# Altium schematic sheet RCU_v2_Logic.SchDoc(RCU_v2_Logic)
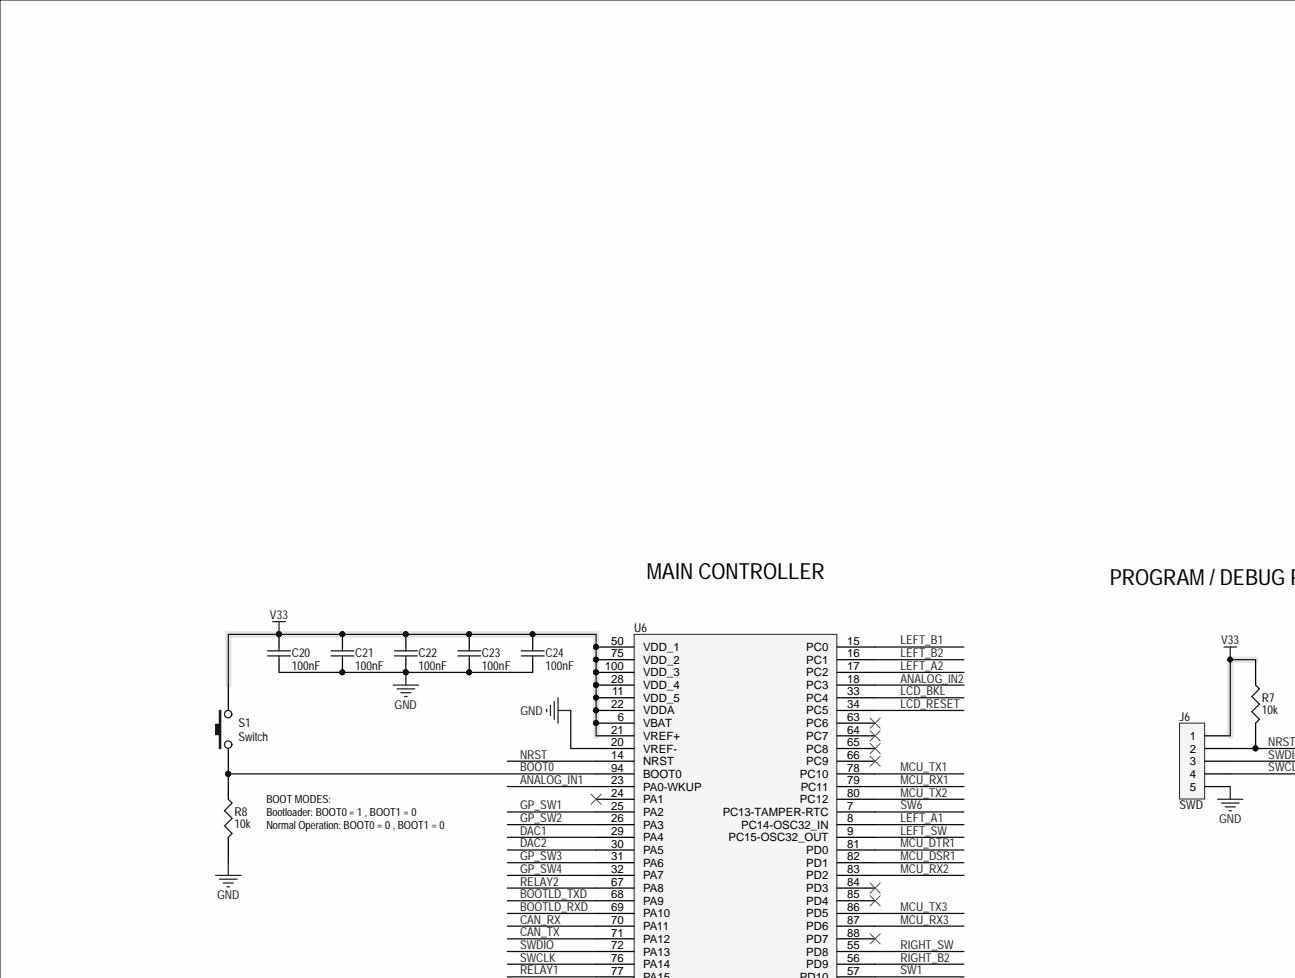
[diagram p0: RCU_v2_Logic.SchDoc, top left]
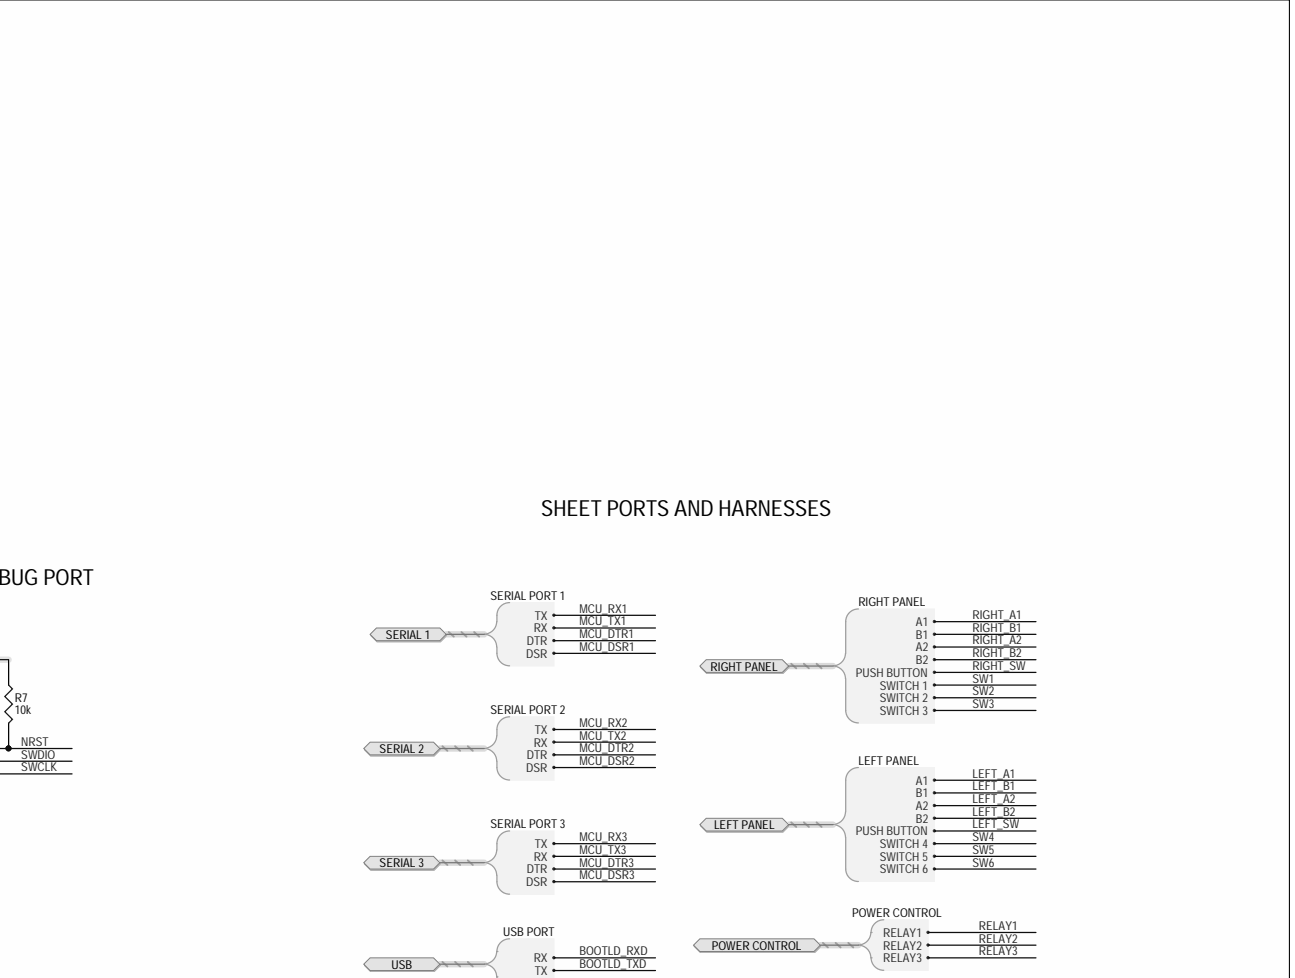
[diagram p1: RCU_v2_Logic.SchDoc, top right]
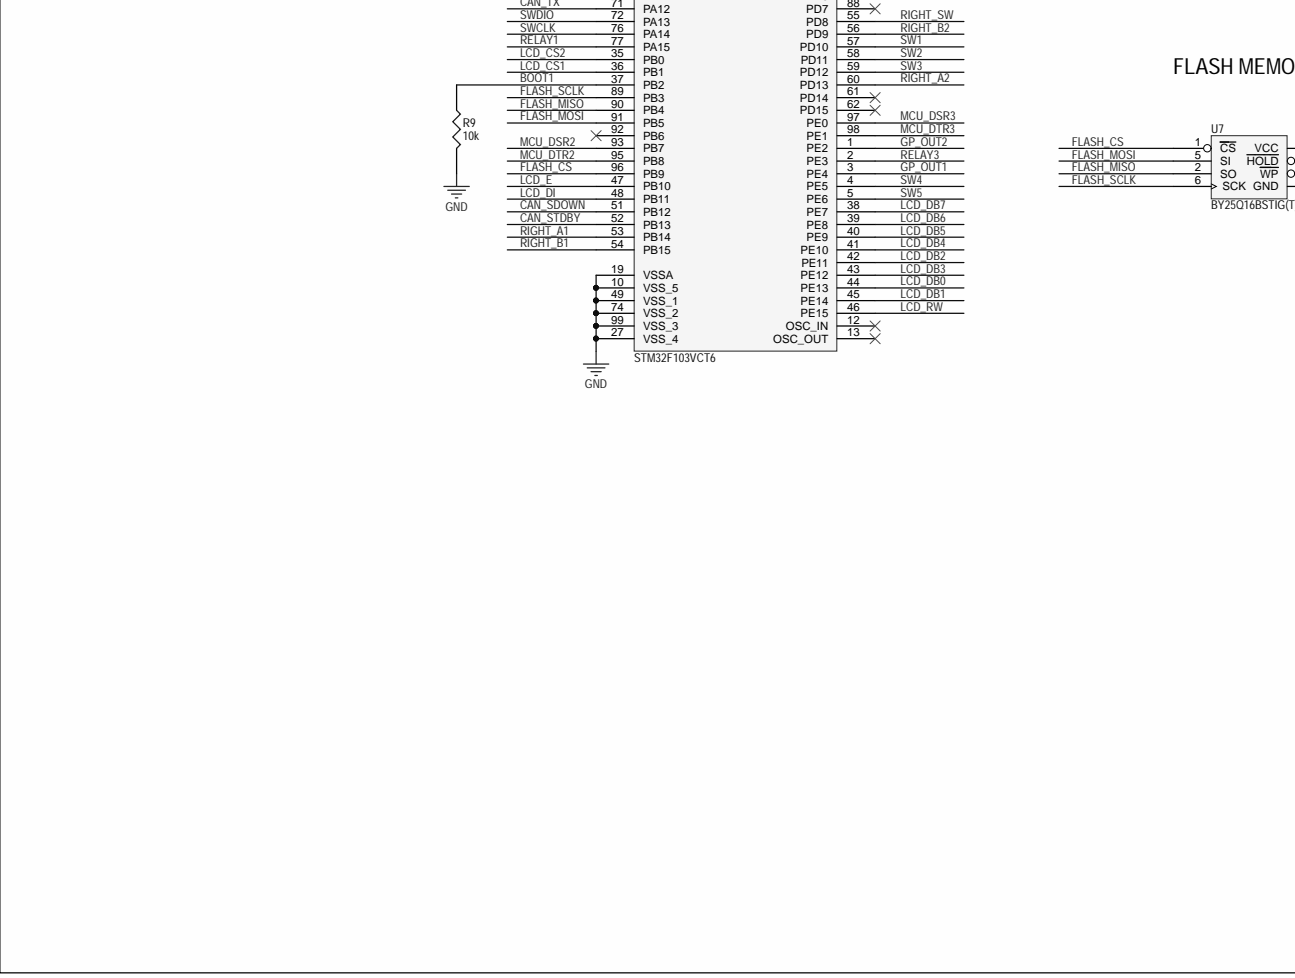
[diagram p2: RCU_v2_Logic.SchDoc, bottom left]
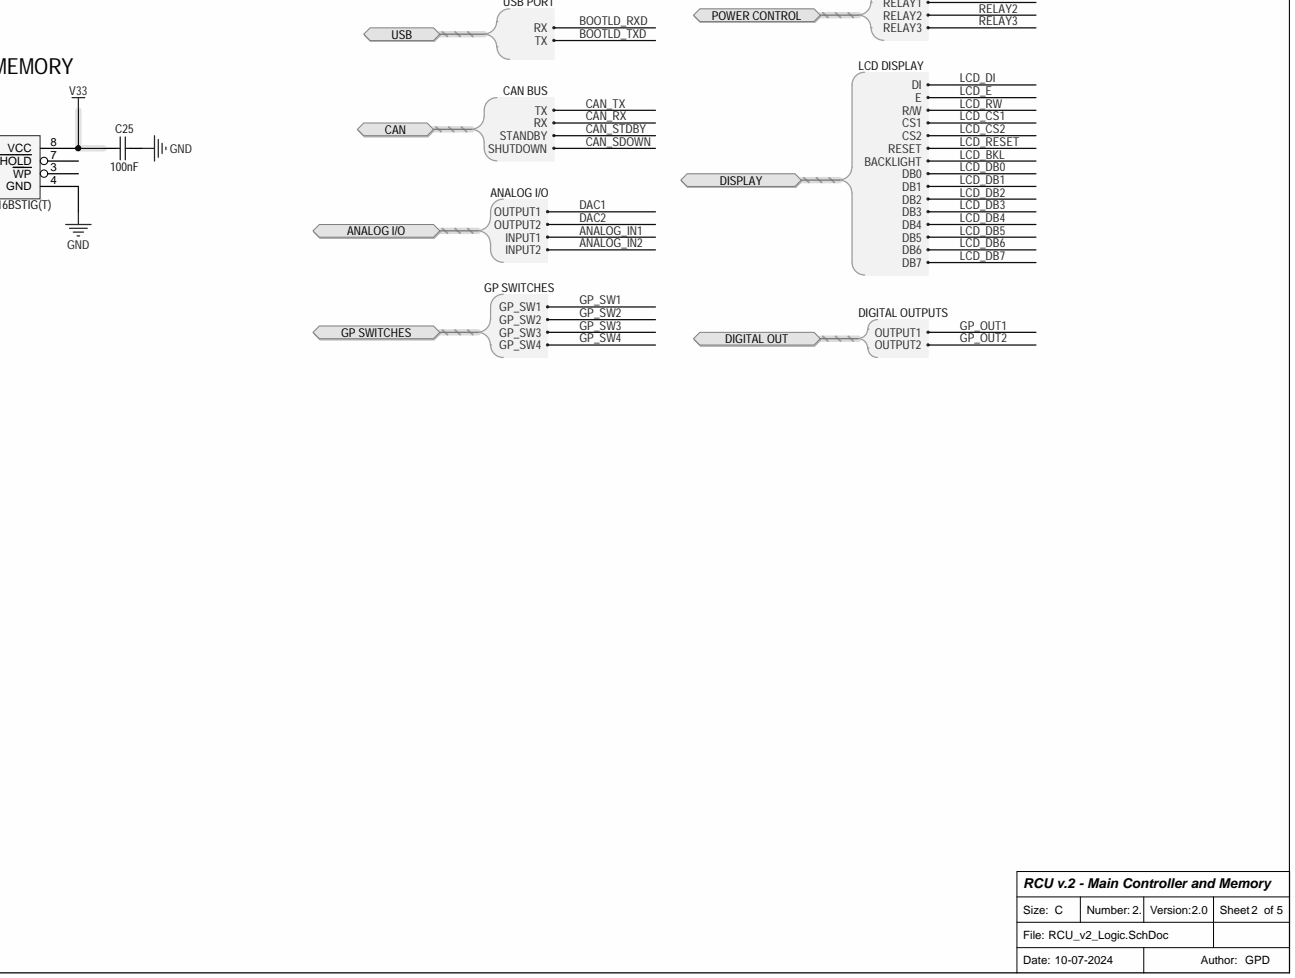
[diagram p3: RCU_v2_Logic.SchDoc, bottom right]

## Parts
C20  100nF  [C0402]
C21  100nF  [C0402]
C22  100nF  [C0402]
C23  100nF  [C0402]
C24  100nF  [C0402]
C25  100nF  [C0402]
J6  SWD  [HDR1X5]
R7  10k  [R0402]
R8  10k  [R0402]
R9  10k  [R0402]
S1  Switch  [SWITCH_A06_RA]
U6  STM32F103VCT6  [F-QFP14X14-G100/N]
U7  BY25Q16BSTIG(T)  [SO-G8]

## Ports
ANALOG I/O, ANALOG I/O.INPUT1, ANALOG I/O.INPUT2, ANALOG I/O.OUTPUT1, ANALOG I/O.OUTPUT2, CAN, CAN.RX, CAN.SHUTDOWN, CAN.STANDBY, CAN.TX, DIGITAL OUT, DIGITAL OUT.OUTPUT1, DIGITAL OUT.OUTPUT2, DISPLAY, DISPLAY.BACKLIGHT, DISPLAY.CS1, DISPLAY.CS2, DISPLAY.DB0, DISPLAY.DB1, DISPLAY.DB2, DISPLAY.DB3, DISPLAY.DB4, DISPLAY.DB5, DISPLAY.DB6, DISPLAY.DB7, DISPLAY.DI, DISPLAY.E, DISPLAY.R/W, DISPLAY.RESET, GP SWITCHES, GP SWITCHES.GP_SW1, GP SWITCHES.GP_SW2, GP SWITCHES.GP_SW3, GP SWITCHES.GP_SW4, LEFT PANEL, LEFT PANEL.A1, LEFT PANEL.A2, LEFT PANEL.B1, LEFT PANEL.B2, LEFT PANEL.PUSH BUTTON, LEFT PANEL.SWITCH 4, LEFT PANEL.SWITCH 5, LEFT PANEL.SWITCH 6, POWER CONTROL, POWER CONTROL.RELAY1, POWER CONTROL.RELAY2, POWER CONTROL.RELAY3, RIGHT PANEL, RIGHT PANEL.A1, RIGHT PANEL.A2, RIGHT PANEL.B1, RIGHT PANEL.B2, RIGHT PANEL.PUSH BUTTON, RIGHT PANEL.SWITCH 1, RIGHT PANEL.SWITCH 2, RIGHT PANEL.SWITCH 3, SERIAL 1, SERIAL 1.DSR, SERIAL 1.DTR, SERIAL 1.RX, SERIAL 1.TX, SERIAL 2, SERIAL 2.DSR, SERIAL 2.DTR, SERIAL 2.RX, SERIAL 2.TX, SERIAL 3, SERIAL 3.DSR, SERIAL 3.DTR, SERIAL 3.RX, SERIAL 3.TX, USB, USB.RX, USB.TX

## Nets
GND: C20.2 C21.2 C22.2 C23.2 C24.2 C25.1 J6.5 R8.2 R9.2 U6.10(VSS_5) U6.19(VSSA) U6.20(VREF-) U6.27(VSS_4) U6.49(VSS_1) U6.74(VSS_2) U6.99(VSS_3) U7.4(GND)
V33: C20.1 C21.1 C22.1 C23.1 C24.1 C25.2 J6.1 R7.1 S1.1 U6.6(VBAT) U6.11(VDD_5) U6.21(VREF+) U6.22(VDDA) U6.28(VDD_4) U6.50(VDD_1) U6.75(VDD_2) U6.100(VDD_3) U7.8(VCC)
ANALOG_IN1: U6.23(PA0-WKUP) ; port ANALOG I/O
ANALOG_IN2: U6.18(PC3) ; port ANALOG I/O
BOOT0: R8.1 S1.2 U6.94(BOOT0)
BOOT1: R9.1 U6.37(PB2)
BOOTLD_RXD: U6.69(PA10) ; port USB
BOOTLD_TXD: U6.68(PA9) ; port USB
CAN_RX: U6.70(PA11) ; port CAN
CAN_SDOWN: U6.51(PB12) ; port CAN
CAN_STDBY: U6.52(PB13) ; port CAN
CAN_TX: U6.71(PA12) ; port CAN
DAC1: U6.29(PA4) ; port ANALOG I/O
DAC2: U6.30(PA5) ; port ANALOG I/O
FLASH_CS: U6.96(PB9) U7.1(CS)
FLASH_MISO: U6.90(PB4) U7.2(SO)
FLASH_MOSI: U6.91(PB5) U7.5(SI)
FLASH_SCLK: U6.89(PB3) U7.6(SCK)
GP_OUT1: U6.3(PE4) ; port DIGITAL OUT
GP_OUT2: U6.1(PE2) ; port DIGITAL OUT
GP_SW1: U6.25(PA2) ; port GP SWITCHES
GP_SW2: U6.26(PA3) ; port GP SWITCHES
GP_SW3: U6.31(PA6) ; port GP SWITCHES
GP_SW4: U6.32(PA7) ; port GP SWITCHES
LCD_BKL: U6.33(PC4) ; port DISPLAY
LCD_CS1: U6.36(PB1) ; port DISPLAY
LCD_CS2: U6.35(PB0) ; port DISPLAY
LCD_DB0: U6.44(PE13) ; port DISPLAY
LCD_DB1: U6.45(PE14) ; port DISPLAY
LCD_DB2: U6.42(PE11) ; port DISPLAY
LCD_DB3: U6.43(PE12) ; port DISPLAY
LCD_DB4: U6.41(PE10) ; port DISPLAY
LCD_DB5: U6.40(PE9) ; port DISPLAY
LCD_DB6: U6.39(PE8) ; port DISPLAY
LCD_DB7: U6.38(PE7) ; port DISPLAY
LCD_DI: U6.48(PB11) ; port DISPLAY
LCD_E: U6.47(PB10) ; port DISPLAY
LCD_RESET: U6.34(PC5) ; port DISPLAY
LCD_RW: U6.46(PE15) ; port DISPLAY
LEFT_A1: U6.8(PC14-OSC32_IN) ; port LEFT PANEL
LEFT_A2: U6.17(PC2) ; port LEFT PANEL
LEFT_B1: U6.15(PC0) ; port LEFT PANEL
LEFT_B2: U6.16(PC1) ; port LEFT PANEL
LEFT_SW: U6.9(PC15-OSC32_OUT) ; port LEFT PANEL
MCU_DSR1: U6.82(PD1) ; port SERIAL 1
MCU_DSR2: U6.93(PB7) ; port SERIAL 2
MCU_DSR3: U6.97(PE0) ; port SERIAL 3
MCU_DTR1: U6.81(PD0) ; port SERIAL 1
MCU_DTR2: U6.95(PB8) ; port SERIAL 2
MCU_DTR3: U6.98(PE1) ; port SERIAL 3
MCU_RX1: U6.79(PC11) ; port SERIAL 1
MCU_RX2: U6.83(PD2) ; port SERIAL 2
MCU_RX3: U6.87(PD6) ; port SERIAL 3
MCU_TX1: U6.78(PC10) ; port SERIAL 1
MCU_TX2: U6.80(PC12) ; port SERIAL 2
MCU_TX3: U6.86(PD5) ; port SERIAL 3
NRST: J6.2 R7.2 U6.14(NRST)
RELAY1: U6.77(PA15) ; port POWER CONTROL
RELAY2: U6.67(PA8) ; port POWER CONTROL
RELAY3: U6.2(PE3) ; port POWER CONTROL
RIGHT_A1: U6.53(PB14) ; port RIGHT PANEL
RIGHT_A2: U6.60(PD13) ; port RIGHT PANEL
RIGHT_B1: U6.54(PB15) ; port RIGHT PANEL
RIGHT_B2: U6.56(PD9) ; port RIGHT PANEL
RIGHT_SW: U6.55(PD8) ; port RIGHT PANEL
SW1: U6.57(PD10) ; port RIGHT PANEL
SW2: U6.58(PD11) ; port RIGHT PANEL
SW3: U6.59(PD12) ; port RIGHT PANEL
SW4: U6.4(PE5) ; port LEFT PANEL
SW5: U6.5(PE6) ; port LEFT PANEL
SW6: U6.7(PC13-TAMPER-RTC) ; port LEFT PANEL
SWCLK: J6.4 U6.76(PA14)
SWDIO: J6.3 U6.72(PA13)

Not connected: U6 12(OSC_IN) 13(OSC_OUT) 24(PA1) 61(PD14) 62(PD15) 63(PC6) 64(PC7) 65(PC8) 66(PC9) 84(PD3) 85(PD4) 88(PD7) 92(PB6); U7 3(WP) 7(HOLD)

## Library data of the parts
C20-C25 = CAP: 100nF ±10% 16V X7R 0402 Multilayer Ceramic Capacitors MLCC - SMD/SMT RoHS; Manufacturer Part Number: C0402C104K4RAC-TU; Manufacturer: KEMET; Value: 100nF; Supplier Part Number 3: 399-C0402C104K4RAC7867DKR-ND; Supplier Part Number 2: 8015145; Supplier Part Number 1: 80-C0402C104K4R; Supplier 3: Digikey; Supplier 2: Rs; Supplier 1: Mouser; Library Name: Miscellaneous Devices.SchLib; Component Kind: Standard
J6 = CON5: Free pads - do not populate; Value: SWD; Supplier Part Number 3: N/A; Supplier Part Number 2: N/A; Supplier Part Number 1: N/A; Supplier 3: N/A; Supplier 2: N/A; Supplier 1: N/A; Manufacturer Part Number: N/A; Manufacturer: N/A; Library Name: Miscellaneous Devices.SchLib; Component Kind: Standard
R7-R9 = RES1: SMD Chip Resistor, 10 kOhm, ± 5%, 63 mW, 0402 [1005 Metric], Thick Film, General Purpose; Manufacturer Part Number: RT0402BRE0710KL; Manufacturer: Yageo; Value: 10k; Supplier Part Number 3: YAG2305CT-ND; Supplier Part Number 2: YAG2305DKR-ND; Supplier Part Number 1: 603-RT0402BRE0710KL; Supplier 3: Digikey; Supplier 2: Digikey; Supplier 1: Mouser; Library Name: Miscellaneous Devices.SchLib; Component Kind: Standard
S1 = SW-PB: Switch Tactile N.O. SPST Round Button PC Pins 0.05A 12VDC 1.57N Thru-Hole Automotive Bulk; Manufacturer Part Number: SKHHLRA010; Manufacturer: Alps Electric; Value: Switch; Supplier Part Number 3: C383800; Supplier Part Number 2: 688-SKHHLR; Supplier Part Number 1: 4809-SKHHLRA010-ND; Supplier 3: LCSC; Supplier 2: Mouser; Supplier 1: Digikey; Library Name: Miscellaneous Devices.SchLib; Component Kind: Standard
U6 = STM32F103VCT6: MCU 32-bit STM32F1 ARM Cortex M3 RISC 256KB Flash 2.5V/3.3V 100-Pin LQFP Tray; Manufacturer: STMicroelectronics; Value: STM32F103VCT6; Supplier Part Number 3: 1624139; Supplier Part Number 2: 511-STM32F103VCT6; Supplier Part Number 1: C8313; Supplier 3: Farnell; Supplier 2: Mouser; Supplier 1: LCSC; Manufacturer Part Number: STM32F103VCT6; Library Name: STM32.SchLib; ComponentLink1URL: https://datasheet.ciiva.com/8054/1831497-8054965.pdf; ComponentLink1Description: Datasheet; Component Kind: Standard
U7 = AT25F2048: SOP-8 NOR FLASH ROHS; Manufacturer: BOYAMICRO; Value: BY25Q16BSTIG(T); Supplier Part Number 3: C382744; Supplier Part Number 2: C382744; Supplier Part Number 1: C382744; Supplier 3: LCSC; Supplier 2: LCSC; Supplier 1: LCSC; Manufacturer Part Number: BY25Q16BSTIG(T); Library Name: ICs.SchLib; ComponentLink1URL: https://datasheet.lcsc.com/lcsc/1912111437_BOYAMICRO-BY25Q16BSTIG-T_C382744.pdf?_gl=1*72e4c3*_gcl_au*MTUzNDU1MTE0Mi4xNzE0NDQxNzc1*_ga*NDgwNzM5Njg2LjE3MTQ0NDE3Nzc.*_ga_98M84MKSZH*MTcxNTI5MzI1My4xNS4xLjE3MTUyOTMyNTQuNTkuMC4w; ComponentLink1Description: Datasheet; Component Kind: Standard
